# Altium schematic sheet FuzzerFuça.SchDoc
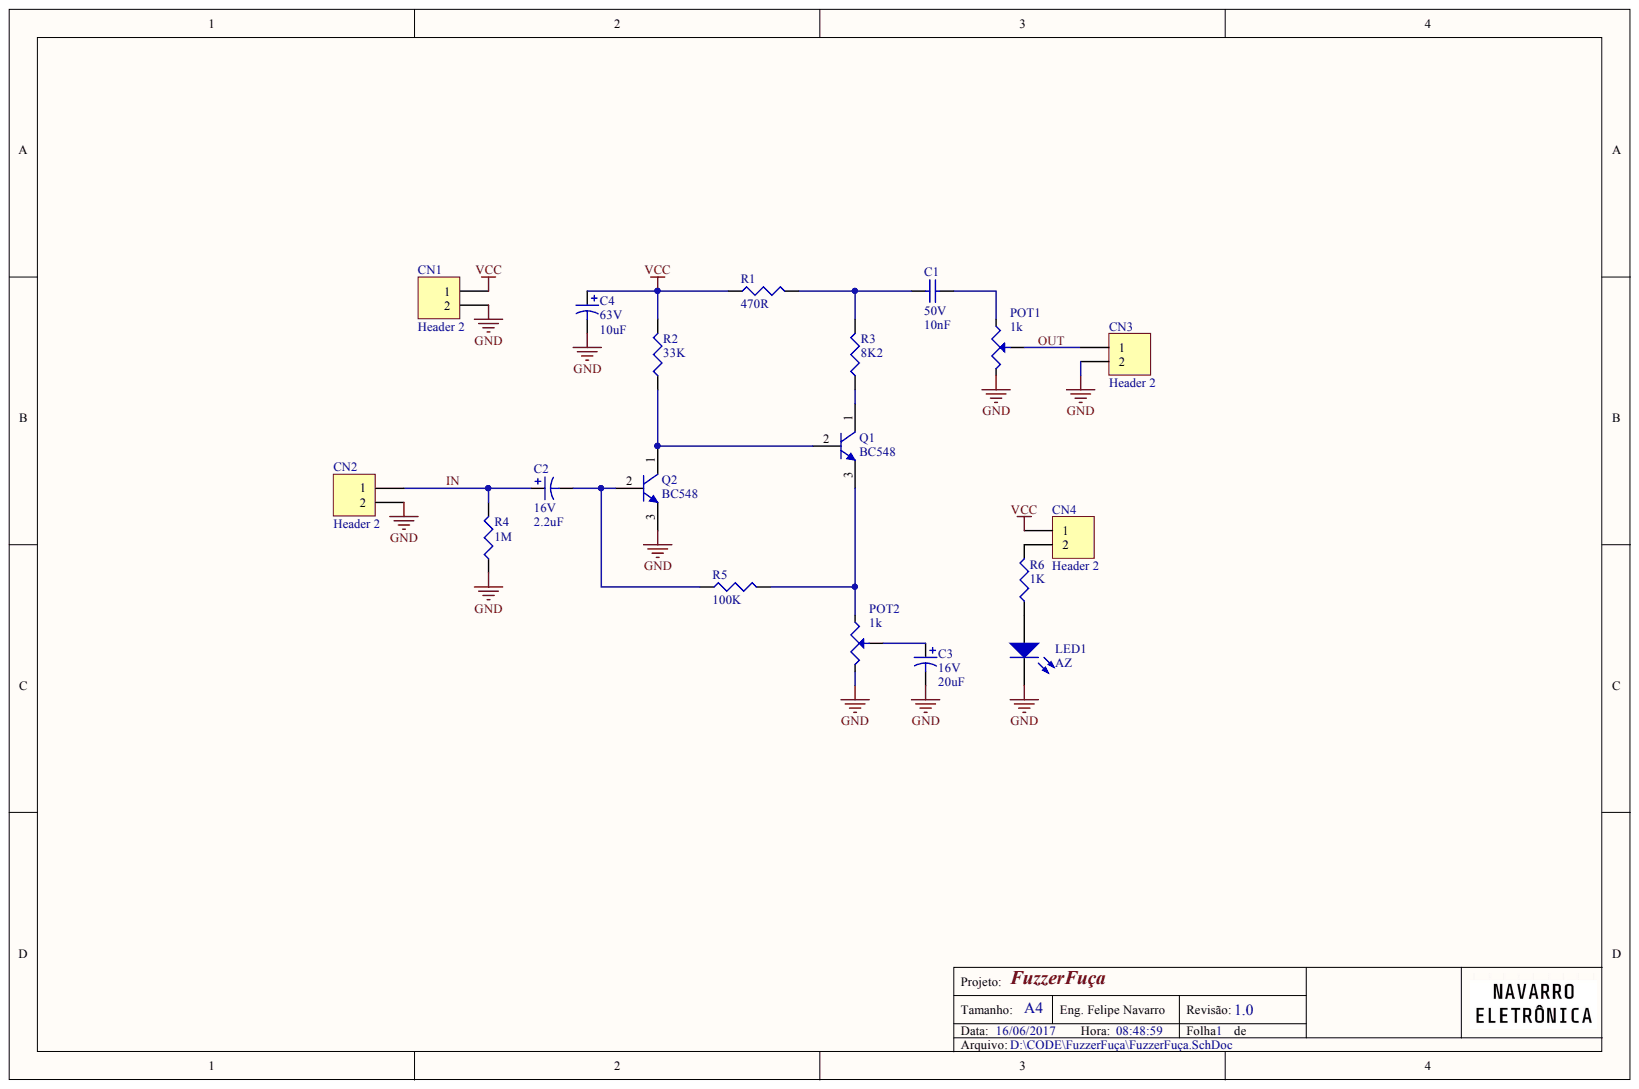
[diagram p0: FuzzerFuça.SchDoc]

## Parts
C1  value 10nF  Tensão: 50V  [CCERAMIC-02I]  (hidden comment: Capacitor Cerâmico)
C2  value 2.2uF  Tensão: 16V  [CPOLRAD5X11]  (hidden comment: Capacitor Tântalo)
C3  value 20uF  Tensão: 16V  [CPOLRAD5X11]  (hidden comment: Capacitor Tântalo)
C4  value 10uF  Tensão: 63V  [CPOLRAD5X11]  (hidden comment: Capacitor Eletrolítico)
CN1  Header 2  [CONN HDR1X2]
CN2  Header 2  [CONN HDR1X2]
CN3  Header 2  [CONN HDR1X2]
CN4  Header 2  [CONN HDR1X2]
LED1  value AZ  [LED 5MM]  (hidden comment: LED TH 5MM AZ)
POT1  value 1k  [POT - GENERICO]  (hidden comment: Potenciômetro)
POT2  value 1k  [POT - GENERICO]  (hidden comment: Potenciômetro)
Q1  BC548  [TO-92]
Q2  BC548  [TO-92]
R1  value 470R  [Axial 0.125W/H]  (hidden comment: Resistor)
R2  value 33K  [Axial 0.125W/H]  (hidden comment: Resistor)
R3  value 8K2  [Axial 0.125W/H]  (hidden comment: Resistor)
R4  value 1M  [Axial 0.125W/H]  (hidden comment: Resistor)
R5  value 100K  [Axial 0.125W/H]  (hidden comment: Resistor)
R6  value 1K  [Axial 0.125W/H]  (hidden comment: Resistor)

## Nets
GND: C3.2 C4.2 CN1.2 CN2.2 CN3.2 LED1.2 POT1.3 POT2.3 Q2.3 R4.1
VCC: C4.1 CN1.1 CN4.1 R1.1 R2.2
IN: C2.1 CN2.1 R4.2
OUT: CN3.1 POT1.2
NetC1_1: C1.1 R1.2 R3.2
NetC1_2: C1.2 POT1.1
NetC2_2: C2.2 Q2.2 R5.1
NetC3_1: C3.1 POT2.2
NetCN4_2: CN4.2 R6.2
NetLED1_1: LED1.1 R6.1
NetPOT2_1: POT2.1 Q1.3 R5.2
NetQ1_1: Q1.1 R3.1
NetQ1_2: Q1.2 Q2.1 R2.1

## Library data of the parts
C1 = Cap 10nF 50V 0603 X7R 5%: Capacitor (Semiconductor SIM Model); ChinesePrice: 0,00320; Chinês: Local; Corrente: *A; Obs: X7R; Potência: *W; Tolerância: ±5%; Component Kind: Standard; ComponentLink1Description: Datasheet; Simulation: CAP; Supplier 1: Digi-Key; Supplier Part Number 1: 399-1092-1-ND; ComponentLink1URL: D:\Tecnoclade\Datasheet\!DataMissing.pdf; Library Name: NavarroCAP.SchLib
C2 C3 = PCap 2.2uF 16V 1206 LOESR: Polarized Capacitor; ChinesePrice: 0,00000; Chinês: ----; Corrente: *A; Obs: LOESR (6R); Potência: *W; Tolerância: ±10%; Component Kind: Standard; ComponentLink1Description: Datasheet; Simulation: CAP; Supplier 1: Digi-Key; Supplier Part Number 1: 399-3691-1-ND; ComponentLink1URL: D:\Tecnoclade\Datasheet\!DataMissing.pdf; Library Name: NavarroCAP.SchLib
C4 = PCap 10uF 63V 5d.x13h: Polarized Capacitor (Radial); ChinesePrice: 0,00000; Chinês: ----; Corrente: *A; Obs: UPM1J100MDD1TD - Alumínio; Potência: *W; Tolerância: ±20%; Component Kind: Standard; ComponentLink1Description: Datasheet; Simulation: CAP; Supplier 1: Digi-Key; Supplier Part Number 1: 493-7402-1-ND; ComponentLink1URL: D:\Tecnoclade\Datasheet\!DataMissing.pdf; Library Name: NavarroCAP.SchLib
CN1-CN4 = Header 2: Header, 2-Pin; ChinesePrice: 0,10000; Chinês: Proved - Local; Value: Barra de 40 pinos - ATENÇÃO; Component Kind: Standard; ComponentLink1Description: Datasheet; Supplier 1: Digi-Key; Supplier Part Number 1: A26509-40-ND; ComponentLink1URL: D:\Tecnoclade\Datasheet\!DataMissing.pdf; Library Name: NavarroCON.SchLib
LED1 = LED TH 5MM AZ: LED; ChinesePrice: 0,00000; Chinês: ----; Tolerância: 2V7; Component Kind: Standard; ComponentLink1Description: Datasheet; Simulation: LED0; Supplier 1: Digi-Key; Supplier Part Number 1: 732-5019-ND; ComponentLink1URL: D:\Tecnoclade\Datasheet\!DataMissing.pdf; Library Name: NavarroSEMI.SchLib
POT1 POT2 = POT 100k Audio: Potenciometro; ChinesePrice: 0,00000; Chinês: ----; Corrente: *A; Potência: *W; Tensão: *V; Tolerância: ±*%; Component Kind: Standard; ComponentLink1Description: Datasheet; Simulation: POT; Supplier 1: Digi-Key; Supplier Part Number 1: PTV09A-2020F-B104-ND; ComponentLink1URL: D:\Tecnoclade\Datasheet\!DataMissing.pdf; Library Name: NavarroRES.SchLib
Q1 Q2 = TRS BC548 30V 100mA TO-92: NPN General Purpose Amplifier; ChinesePrice: 0,00000; Chinês: ----; Corrente: 100mA; Tensão: 30V; Value: 300MHz; Component Kind: Standard; ComponentLink1Description: Datasheet; Simulation: 2N3904; Supplier 1: Digi-Key; Supplier Part Number 1: BC548CTAFSCT-ND; ComponentLink1URL: D:\Tecnoclade\DatasheetBC548x.pdf; Library Name: NavarroSEMI.SchLib
R1 = Res 470R 5% 0603 1/10W: ChinesePrice: 0,00000; Chinês: ----; Corrente: *A; Obs: ±200ppm/ºC; Potência: 1/10W; Tensão: *V; Tolerância: ±5%; Component Kind: Standard; ComponentLink1Description: Datasheet; Description: Resistor; Simulation: RESISTOR; Supplier 1: Digi-Key; Supplier Part Number 1: RMCF0603JT470RCT-ND; ComponentLink1URL: D:\Tecnoclade\Datasheet\!DataMissing.pdf; Library Name: NavarroRES.SchLib
R2 = Res 33K 1% 0603 1/10W: ChinesePrice: 0,00000; Chinês: ----; Corrente: *A; Obs: ±100ppm/ºC; Potência: 1/10W; Tensão: *V; Tolerância: ±1%; Component Kind: Standard; ComponentLink1Description: Datasheet; Description: Resistor; Simulation: RESISTOR; Supplier 1: Digi-Key; Supplier Part Number 1: RMCF0603FT33K0CT-ND; ComponentLink1URL: D:\Tecnoclade\Datasheet\!DataMissing.pdf; Library Name: NavarroRES.SchLib
R3 R6 = Res 18K2 1% 0603 1/8W: ChinesePrice: 0,00000; Chinês: ----; Corrente: *A; Obs: ±100ppm/ºC; Potência: 1/8W; Tensão: *V; Tolerância: ±1%; Component Kind: Standard; ComponentLink1Description: Datasheet; Description: Resistor; Simulation: RESISTOR; Supplier 1: Digi-Key; ComponentLink1URL: D:\Tecnoclade\Datasheet\!DataMissing.pdf; Library Name: NavarroRES.SchLib
R4 = Res 1M 1% 0603 1/10W: ChinesePrice: 0,00089; Chinês: Local; Corrente: *A; Obs: ±100ppm/ºC; Potência: 1/10W; Tensão: *V; Tolerância: ±1%; Component Kind: Standard; ComponentLink1Description: Datasheet; Description: Resistor; Simulation: RESISTOR; Supplier 1: Digi-Key; Supplier Part Number 1: RMCF0603FT1M00CT-ND; ComponentLink1URL: D:\Tecnoclade\Datasheet\!DataMissing.pdf; Library Name: NavarroRES.SchLib
R5 = Res 100K 1% 0603 1/10W: ChinesePrice: 0,00089; Chinês: Local; Corrente: *A; Potência: 1/10W; Tensão: *V; Tolerância: ±1%; Component Kind: Standard; ComponentLink1Description: Datasheet; Description: Resistor; Simulation: RESISTOR; Supplier 1: Digi-Key; Supplier Part Number 1: P100KHCT-ND; ComponentLink1URL: D:\Tecnoclade\Datasheet\!DataMissing.pdf; Library Name: NavarroRES.SchLib
